# Altium schematic sheet LEDs.SchDoc
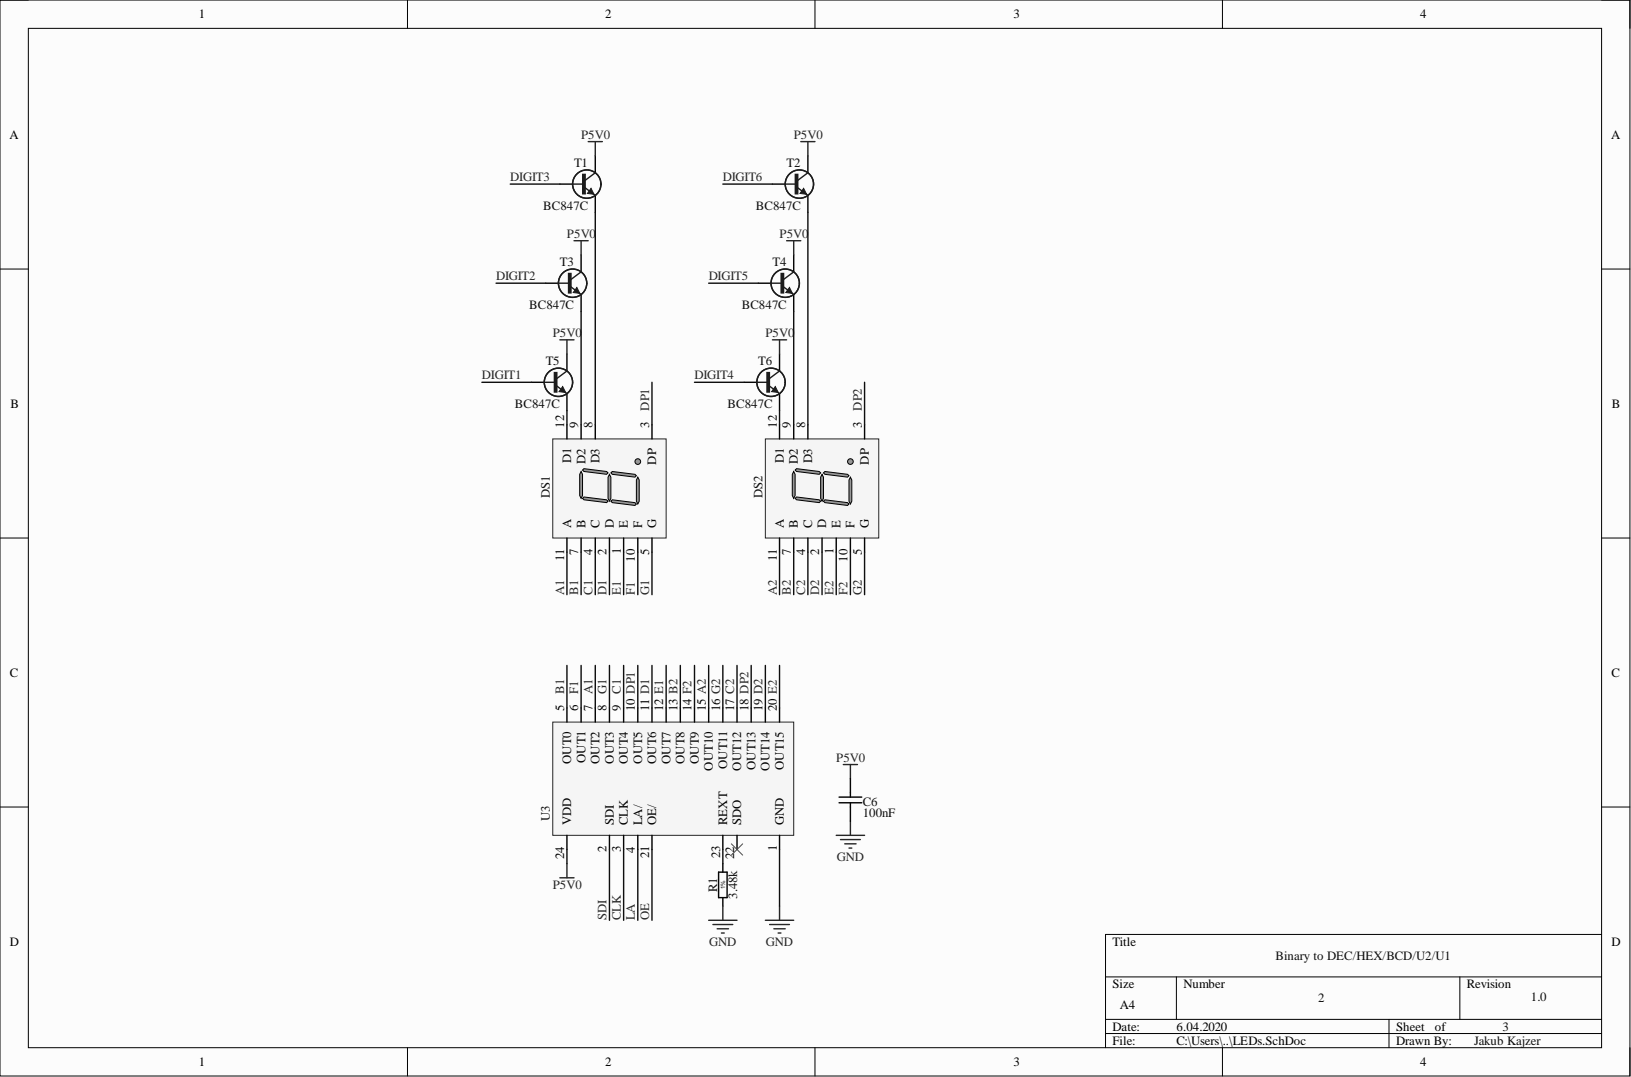
[diagram p0: LEDs.SchDoc]

## Parts
C6  100nF  [CAPC0402X60N]
DS1  (no value)
DS2  (no value)
R1  3.48k  Tolerance: 1%  [RESC0402X40N]
T1  BC847C  [SOT23-3]
T2  BC847C  [SOT23-3]
T3  BC847C  [SOT23-3]
T4  BC847C  [SOT23-3]
T5  BC847C  [SOT23-3]
T6  BC847C  [SOT23-3]
U3  (no value)

## Nets
GND: C6.1 R1.1 U3.1(GND)
P5V0: C6.2 T1.3 T2.3 T3.3 T4.3 T5.3 T6.3 U3.24(VDD)
A1: DS1.11(A) U3.7(OUT2)
A2: DS2.11(A) U3.15(OUT10)
B1: DS1.7(B) U3.5(OUT0)
B2: DS2.7(B) U3.13(OUT8)
C1: DS1.4(C) U3.9(OUT4)
C2: DS2.4(C) U3.17(OUT12)
CLK: U3.3(CLK)
D1: DS1.2(D) U3.11(OUT6)
D2: DS2.2(D) U3.19(OUT14)
DIGIT1: T5.1
DIGIT2: T3.1
DIGIT3: T1.1
DIGIT4: T6.1
DIGIT5: T4.1
DIGIT6: T2.1
DP1: DS1.3(DP) U3.10(OUT5)
DP2: DS2.3(DP) U3.18(OUT13)
E1: DS1.1(E) U3.12(OUT7)
E2: DS2.1(E) U3.20(OUT15)
F1: DS1.10(F) U3.6(OUT1)
F2: DS2.10(F) U3.14(OUT9)
G1: DS1.5(G) U3.8(OUT3)
G2: DS2.5(G) U3.16(OUT11)
LA: U3.4(LA/)
OE: U3.21(OE/)
SDI: U3.2(SDI)
NetDS1_8: DS1.8(D3) T1.2
NetDS1_9: DS1.9(D2) T3.2
NetDS1_12: DS1.12(D1) T5.2
NetDS2_8: DS2.8(D3) T2.2
NetDS2_9: DS2.9(D2) T4.2
NetDS2_12: DS2.12(D1) T6.2
NetR1_2: R1.2 U3.23(REXT)

Not connected: U3 22(SDO)

## Library data of the parts
C6 = CC0402_100NF_16V_10%_X7R: Non-polarised capacitor; Manufacturer: GENERIC; Manufacturer 1 Component Height: 0.5mm; Manufacturer 1 Example: Samsung; Manufacturer 1 Part Number: CL05B104KO5NNNC; Database Table Name: Capacitors_SMD; Dielectric: X7R; PressFit: No; Tolerance: 10%; Voltage: 16V; Case: 0402 (1005 metric); Component Kind: Standard; Create Date: 29.07.2019; DesignItemId: CC0402_100NF_16V_10%_X7R; HelpURL: http://www.farnell.com/datasheets/1870456.pdf?_ga=2.66904750.1666480506.1564307475-778048469.1556931874&_gac=1.242951606.1563147047.EAIaIQobChMIrfuAvMi14wIVB-J3Ch1XegfpEAYYASABEgIMVfD_BwE; LatestRevisionDate: 29.07.2019; Library Name: Capacitors.DbLib; Manufacturer Part Number: CC0402_100NF_16V_10%_X7R; Mounted: Yes; Part Description: Non-polarised capacitor; Pin Count: 2; Sense: No; SMD: Yes; Socket: No; Status: Recommended; Supplier 1: TME; Supplier 2: Farnell; Supplier 3: Mouser; Supplier Part Number 1: CL05B104KO5NNNC; Supplier Part Number 2: 1758896; Supplier Part Number 3: 710-885012205037; Value: 100nF; Author: KB
DS1 DS2 = 7-Segment Green Display CA 3-Digits: 7-seg LED Display; 9.14mm; 0.36ˇ¨; 3 Digits; Green; Manufacturer: Generic; Manufacturer 1 Component Height: 7.2mm; Manufacturer 1 Example: WENRUN; Manufacturer 1 Part Number: LTD036BAG-101A-02; Database Table Name: LEDs; PressFit: No; Color: Green; Component Kind: Standard; Create Date: 04.12.2019; DesignItemId: 7-Segment Green Display CA 3-Digits; HelpURL: https://www.tme.eu/Document/a6075032405c075918ac13897b6973d4/LTD036BAG-101A.pdf; LatestRevisionDate: 04.12.2019; Library Name: ICs And Semiconductors.DbLib; Mounted: YES; Part Description: 7-seg LED Display; 9.14mm; 0.36ˇ¨; 3 Digits; Green; Pin Count: 12; Sense: No; SMD: No; Socket: No; Supplier 1: TME; Supplier Part Number 1: LTD036BAG-101A; Author: JK
R1 = R0402_3K48_0.0625W_100PPM: General Purpose Thick Film Chip Resistor; Manufacturer: GENERIC; Manufacturer 1 Component Height: 0.4mm; Manufacturer 1 Example: Vishay; Manufacturer 1 Part Number: CRCW04023K48FKEDC; Manufacturer Part Number: R0402_3K48_0.0625W 0.1W 0.125W 0.25W 0.5W 1W 0.75W 1W_100PPM; Current Max: 0; Database Table Name: Resistors_SMD; Power: 62.5mW; PressFit: No; TC: ±100 ppm/°C; Voltage: 50V; Case: 0402 (1005 metric); Component Kind: Standard; Component Type: Standard; Create Date: 03.07.2019; DesignItemId: R0402_3K48_0.0625W_100PPM; HelpURL: https://www.vishay.com/docs/20035/dcrcwe3.pdf; LatestRevisionDate: 03.07.2019; Library Name: Resistors.DbLib; Mounted: Yes; Part Description: General Purpose Thick Film Chip Resistor; Pin Count: 2; Sense: No; SMD: Yes; Socket: No; Status: Recommended; Supplier 1: Farnell; Supplier 2: TME; Supplier 3: Mouser; Value: 3.48k; Author: KB
T1-T6 = BC847C: NPN Bipolar Transistor, 45V, 100mA, 250mW, SOT23; Manufacturer 1 Component Height: 1.05mm; Manufacturer 1 Example: Nexperia; Manufacturer 1 Part Number: BC847C.215; Database Table Name: Transistors; PressFit: No; Component Kind: Standard; Create Date: 19.04.2009; DesignItemId: BC847C; Device: BC847C; HelpURL: https://assets.nexperia.com/documents/data-sheet/BC847_SER.pdf; LatestRevisionDate: 19.04.2009; Library Name: ICs And Semiconductors.DbLib; Mounted: Yes; Part Description: NPN Bipolar Transistor, 45V, 100mA, 250mW, SOT23; Pin Count: 3; Sense: No; SMD: Yes; Socket: No; Supplier 1: TME; Supplier 2: Farnell; Supplier 3: Mouser; Supplier Part Number 1: BC847C.215; Supplier Part Number 2: 1081235; Supplier Part Number 3: 771-BC847C-T/R; Author: KB
U3 = SCT2024: Driver, kontroler LED, 5ˇĹ0mA, Kanały: 16, 3ˇĹ,5V, SOP24; Manufacturer: Generic; Manufacturer 1 Component Height: 2.65mm; Manufacturer 1 Example: STARCHIPS TECHNOLOGY; Manufacturer 1 Part Number: SCT2024CSOG; Database Table Name: LEDs; PressFit: No; Component Kind: Standard; Create Date: 14.08.2019; DesignItemId: SCT2024; HelpURL: https://www.tme.eu/Document/8876e3da3e0cc25d8b4c7cdeea8b8a88/SCT2024.pdf; LatestRevisionDate: 14.08.2019; Library Name: ICs And Semiconductors.DbLib; Mounted: Yes; Part Description: Driver, kontroler LED, 5ˇĹ0mA, Kanały: 16, 3ˇĹ,5V, SOP24; Pin Count: 24; Sense: No; SMD: Yes; Socket: No; Supplier 1: TME; Supplier Part Number 1: SCT2024CSOG; Author: UN
